# Altium schematic sheet Fonte 24V 15A AFS gns isolado.SchDoc
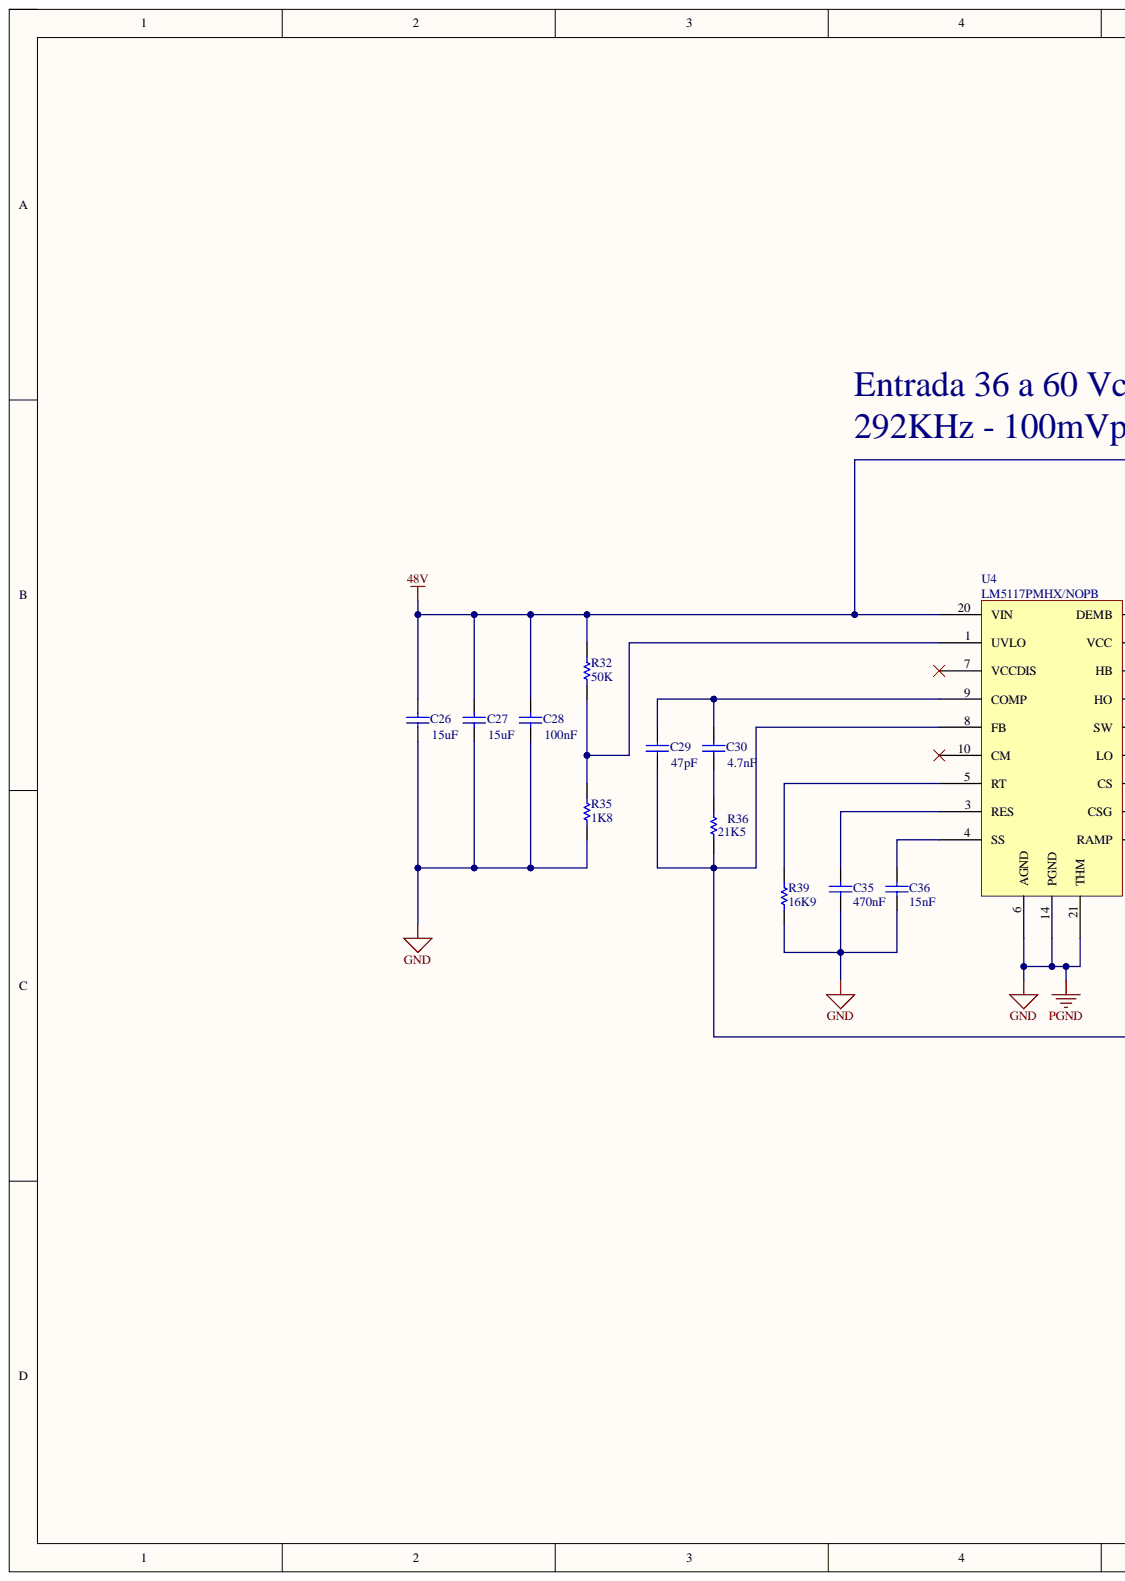
[diagram p0: Fonte 24V 15A AFS gns isolado.SchDoc, left]
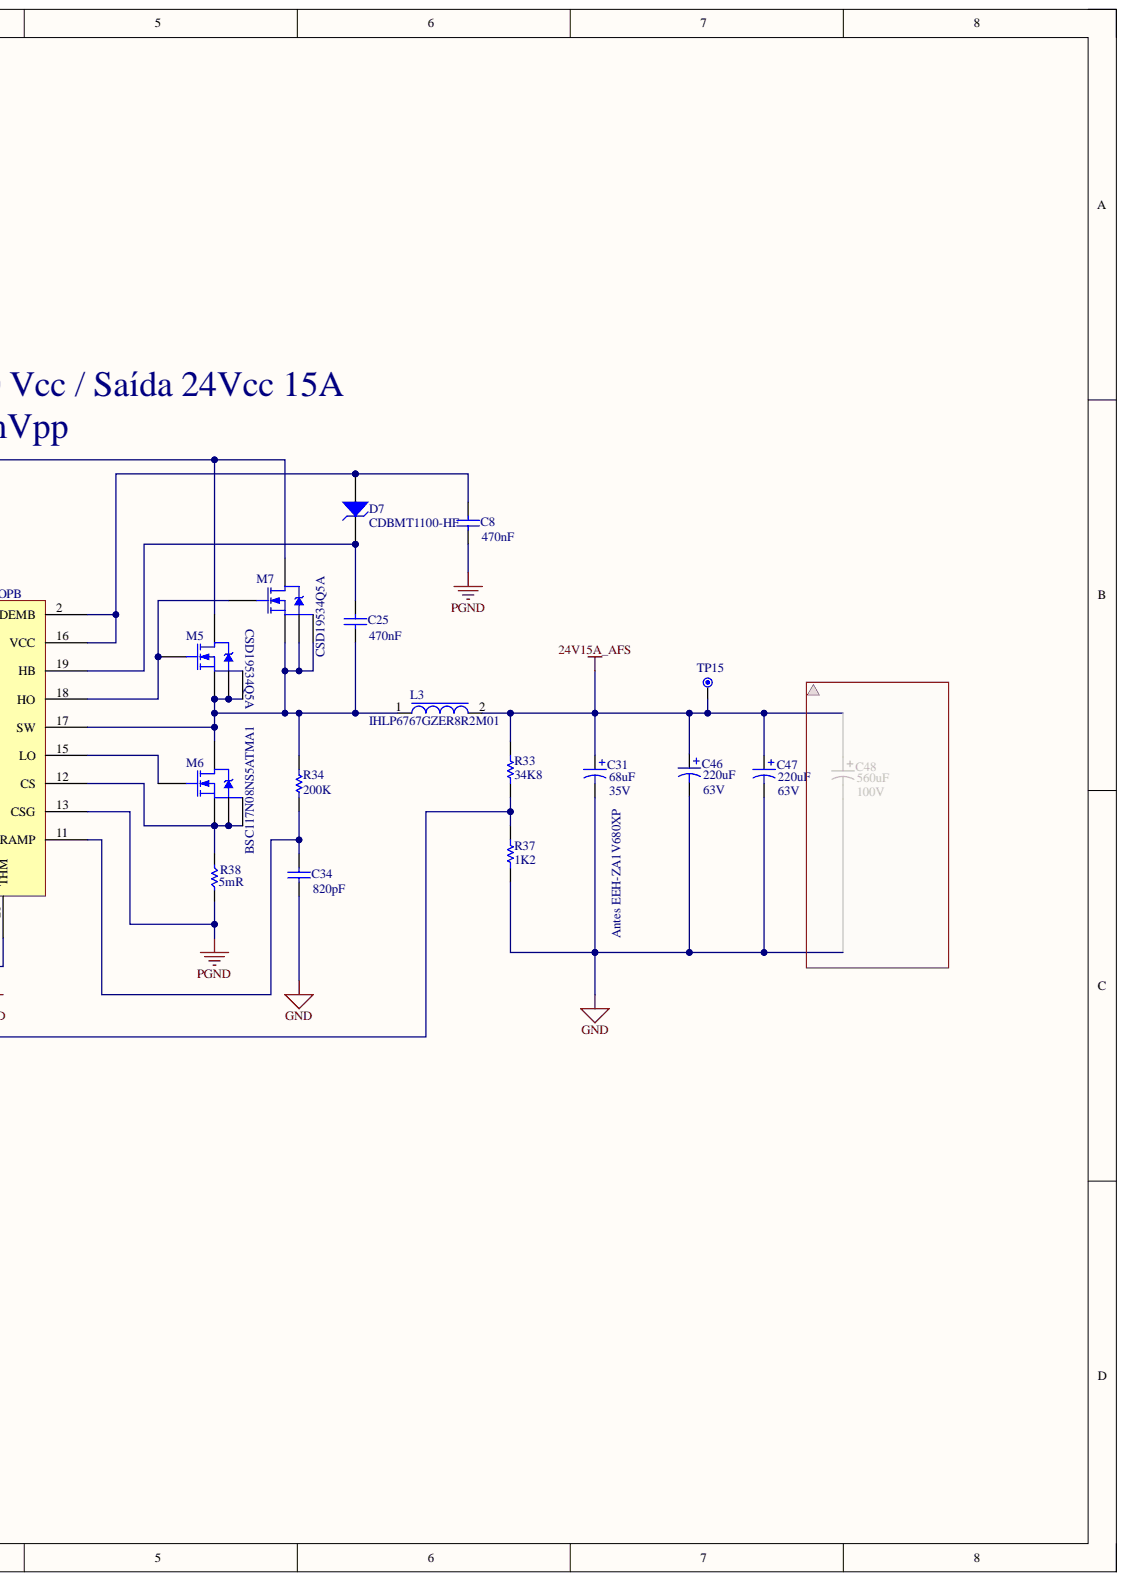
[diagram p1: Fonte 24V 15A AFS gns isolado.SchDoc, right]

## Parts
C8  GRM188R71A474KA61D: 470nF  [SMD_0603]  (hidden comment: GRM188R71A474KA61D)
C25  GRM188R71A474KA61D: 470nF  [SMD_0603]  (hidden comment: GRM188R71A474KA61D)
C26  C5750X7S2A156M250KB: 15uF  [5750]  (hidden comment: C5750X7S2A156M250KB)
C27  C5750X7S2A156M250KB: 15uF  [5750]  (hidden comment: C5750X7S2A156M250KB)
C28  C1608X7S2A104K080AE: 100nF  [SMD_0603]  (hidden comment: C1608X7S2A104K080AE)
C29  GRM1885C1H470JA01D: 47pF  [SMD_0603]  (hidden comment: GRM1885C1H470JA01D)
C30  C0603C472K1TACAUTO: 4.7nF  [SMD_0603]  (hidden comment: C0603C472K1TACAUTO)
C31  870235674004: 68uF  Voltage: 35V  [Cap Aluminio 6,3 com furo]  (hidden comment: 870235674004)
C34  C0603C821J5GACTU: 820pF  [SMD_0603]  (hidden comment: C0603C821J5GACTU)
C35  GRM188R71C474KA88D: 470nF  [SMD_0603]  (hidden comment: GRM188R71C474KA88D)
C36  CGA4F2C0G1H153J085AA: 15nF  [SMD_0603]  (hidden comment: CGA4F2C0G1H153J085AA)
C46  EKYA630ELL221MJ20S: 220uF  Voltage: 63V  [CAP ELE RAD 10 mm]  (hidden comment: EKYA630ELL221MJ20S)
C47  EKYA630ELL221MJ20S: 220uF  Voltage: 63V  [CAP ELE RAD 10 mm]  (hidden comment: EKYA630ELL221MJ20S)
D7  CDBMT1100-HF  [SMD_SOD123-2]
L3  IHLP6767GZER8R2M01  [IHLP6767GZER6R8M11]
M5  CSD19534Q5A  [TDSON_8]
M6  BSC117N08NS5ATMA1  [TDSON_8]
M7  CSD19534Q5A  [TDSON_8]
R32  RT0603BRD0750KL: 50K  [SMD_0603]  (hidden comment: RT0603BRD0750KL)
R33  CRCW060334K8FKEA: 34K8  [SMD_0603]  (hidden comment: CRCW060334K8FKEA)
R34  RMCF0603FT200K: 200K  [SMD_0603]  (hidden comment: RMCF0603FT200K)
R35  RC0603JR-071K8L: 1K8  [SMD_0603]  (hidden comment: RC0603JR-071K8L)
R36  RC0603FR-0721K5L: 21K5  [SMD_0603]  (hidden comment: RC0603FR-0721K5L)
R37  RC0603FR-071K2L: 1K2  [SMD_0603]  (hidden comment: RC0603FR-071K2L)
R38  Resistance: 5mR  [SMD_1206]  (hidden comment: WSL12065L000FTA)
R39  RMCF0603FT16K9: 16K9  [SMD_0603]  (hidden comment: RMCF0603FT16K9)
TP15  TP-1MM  [TESTPOINT-1MM]  (value not drawn)  (no BOM)
U4  LM5117PMHX/NOPB  [HTSSOP-20 (PWP0020A)]

## Nets
24V15A_AFS: C31.1 C46.1 C47.1 L3.2 R33.1 TP15.1
48V: C26.2 C27.2 C28.2 M5.5 M7.5 R32.2 U4.20(VIN)
GND: C8.1 C26.1 C27.1 C28.1 C31.2 C34.1 C35.1 C36.2 C46.2 C47.2 R35.1 R37.2 R38.1 R39.1 U4.6(AGND) U4.13(CSG) U4.14(PGND) U4.21(THM)
NetC8_2: C8.2 D7.1 U4.2(DEMB) U4.16(VCC)
NetC25_1: C25.1 L3.1 M5.1 M5.2 M5.3 M6.5 M7.1 M7.2 M7.3 R34.2 U4.17(SW)
NetC25_2: C25.2 D7.2 U4.19(HB)
NetC29_1: C29.1 R33.2 R36.1 R37.1 U4.8(FB)
NetC29_2: C29.2 C30.2 U4.9(COMP)
NetC30_1: C30.1 R36.2
NetC34_2: C34.2 R34.1 U4.11(RAMP)
NetC35_2: C35.2 U4.3(RES)
NetC36_1: C36.1 U4.4(SS)
NetM5_4: M5.4 M7.4 U4.18(HO)
NetM6_1: M6.1 M6.2 M6.3 R38.2 U4.12(CS)
NetM6_4: M6.4 U4.15(LO)
NetR32_1: R32.1 R35.2 U4.1(UVLO)
NetR39_2: R39.2 U4.5(RT)

Not connected: U4 7(VCCDIS) 10(CM)

## Library data of the parts
C8 C25-C30 C34-C36 = Cap Semi: Capacitor (Semiconductor SIM Model); Component Kind: Standard; Library Name: Miscellaneous Devices.IntLib; PackageReference: C1206; Published: 8-Jun-2000; Signal Integrity: Cap Semi; Simulation: CAP; Publisher: Altium Limited
  C8 C25 C29 C30 C34-C36: LatestRevisionDate: 17-Jul-2002; LatestRevisionNote: Re-released for DXP Platform.
  C26-C28: LatestRevisionDate: 29-May-2009; LatestRevisionNote: IPC-7351 Footprint Added.; PackageDescription: Chip Capacitor
C31 C46 C47 = Cap Pol1: Polarized Capacitor (Radial); Component Kind: Standard; LatestRevisionDate: 17-Jul-2002; LatestRevisionNote: Re-released for DXP Platform.; Library Name: Miscellaneous Devices.IntLib; PackageReference: RB7.6-15; Published: 8-Jun-2000; Signal Integrity: Cap Pol; Simulation: CAP; Publisher: Altium Limited
D7 = BAS316-TP: DIODE 100V 500MA SOD323; Component Kind: Standard; Supplier 1: Digi-Key; Supplier Part Number 1: BAS316-TPMSCT-ND; Library Name: XM_Diodes.SchLib
L3 = 74435588200: FIXED IND 82UH 7A 30.4 MOHM SMD; Component Kind: Standard; Supplier 1: Digi-Key; Supplier Part Number 1: 732-4241-1-ND; Library Name: XM_Inductor.SchLib
M5-M7 = BSC117N08NS5ATMA1: MOSFET N-CH 80V 49A Automotive; Component Kind: Standard; Library Name: XM_Fet.SchLib
R32-R37 R39 = Res Semi: Semiconductor Resistor; Component Kind: Standard; LatestRevisionDate: 17-Jul-2002; LatestRevisionNote: Re-released for DXP Platform.; Library Name: Miscellaneous Devices.IntLib; PackageReference: AXIAL-0.5; Published: 8-Jun-2000; Signal Integrity: Res Semi; Simulation: RES; Publisher: Altium Limited
R38 = R-5mR-1/4W-1%-1206: Resistor 5m ohms 1/4W 1% 1206; Component Kind: Standard; Library Name: WarLib.IntLib; Part Number: WSL12065L000FTA; Power: 1/4W; Tolerance: ±1%
U4 = LM5117PMHX/NOPB: Component Kind: Standard; Library Name: XM_IC.SchLib
TP15 = TP-1MM: Library Name: xmobots_-_comp_library.IntLib
